# Altium schematic sheet Sheet1.SchDoc(Sheet1)
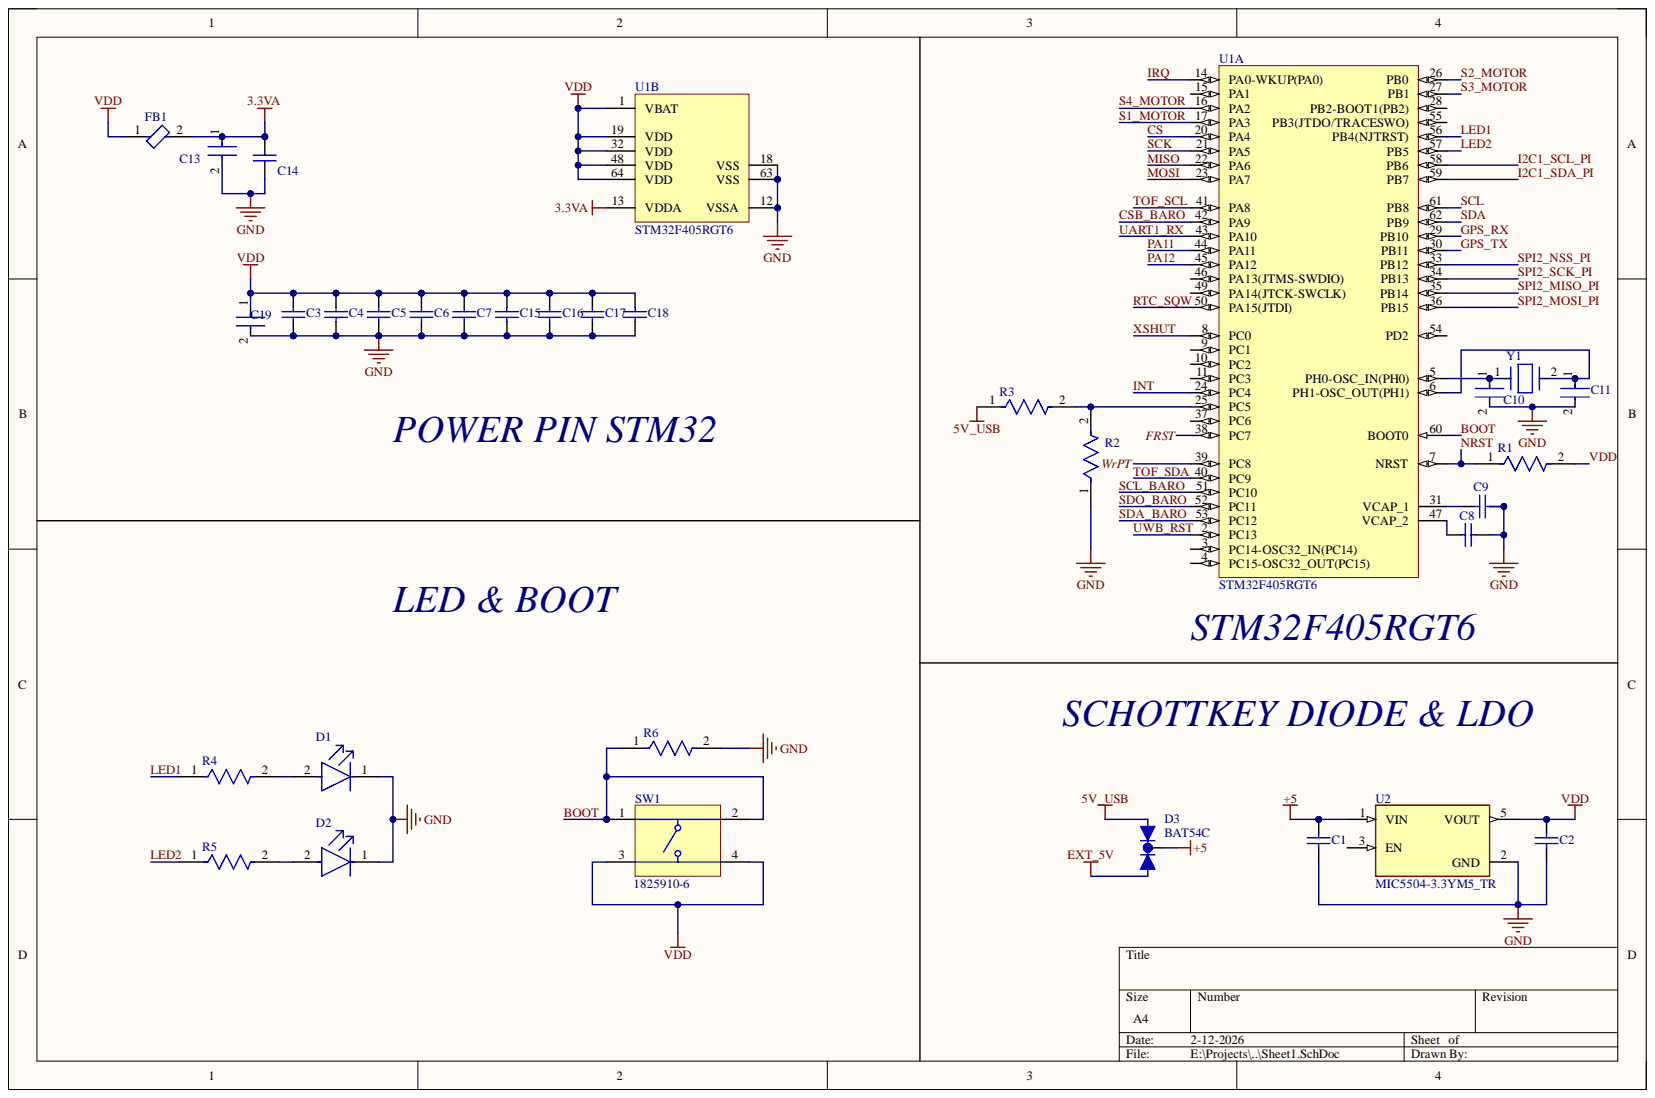
[diagram p0: Sheet1.SchDoc]

## Parts
C1  0603YG105ZAT4A  [CAPC1608X90X35ML15T15]  (value not drawn)
C2  0603YG105ZAT4A  [CAPC1608X90X35ML15T15]  (value not drawn)
C3  VJ0603Y104KXXAP  [CAPC1608X92X38NL15T08]  (value not drawn)
C4  VJ0603Y104KXXAP  [CAPC1608X92X38NL15T08]  (value not drawn)
C5  VJ0603Y104KXXAP  [CAPC1608X92X38NL15T08]  (value not drawn)
C6  VJ0603Y104KXXAP  [CAPC1608X92X38NL15T08]  (value not drawn)
C7  VJ0603Y104KXXAP  [CAPC1608X92X38NL15T08]  (value not drawn)
C8  VJ0603Y104KXXAP  [CAPC1608X92X38NL15T08]  (value not drawn)
C9  VJ0603Y104KXXAP  [CAPC1608X92X38NL15T08]  (value not drawn)
C10  06035A220JAT2A  [FP-0603-L_1_6_0_15-W_0_81-IPC_C]  (value not drawn)
C11  06035A220JAT2A  [FP-0603-L_1_6_0_15-W_0_81-IPC_C]  (value not drawn)
C13  CGA3E2X7R1H104K080AA  [FP-CGA3E-0_3-0_1-IPC_A]  (value not drawn)
C14  GRM188R71H103KA01D  [CAPC1608X90X35ML10T15]  (value not drawn)
C15  VJ0603Y104KXXAP  [CAPC1608X92X38NL15T08]  (value not drawn)
C16  VJ0603Y104KXXAP  [CAPC1608X92X38NL15T08]  (value not drawn)
C17  VJ0603Y104KXXAP  [CAPC1608X92X38NL15T08]  (value not drawn)
C18  VJ0603Y104KXXAP  [CAPC1608X92X38NL15T08]  (value not drawn)
C19  GRM188R60J106ME47D  [FP-GRM188-0_1-e0_2_0_55-IPC_A]  (value not drawn)
D1  LG L29K-G2J1-24-Z  [FP-LG_L29K-MFG]  (value not drawn)
D2  VLMS1300-GS08  [FP-6_541-5092_01-4-MFG]  (value not drawn)
D3  BAT54C  [SOT23-R]
FB1  MPZ2012S101ATD25  [FP-MPZ2012-MFG]  (value not drawn)
R1  AC0603FR-0710KL  [FP-AC0603-MFG]  (value not drawn)
R2  MCT06030C1002FP500  [FP-MCT0603-IPC_C]  (value not drawn)
R3  MCT06030C1002FP500  [FP-MCT0603-IPC_C]  (value not drawn)
R4  AC0603FR-071KL  [FP-AC0603-IPC_B]  (value not drawn)
R5  AC0603FR-071KL  [FP-AC0603-IPC_B]  (value not drawn)
R6  AC0603FR-0710KL  [FP-AC0603-MFG]  (value not drawn)
SW1  1825910-6  [TECO-1825910-6_V]
U1  STM32F405RGT6  [STM-LQFP64_M]  (parts A,B)
U2  MIC5504-3.3YM5_TR  [SOT95P280X145-5N]
Y1  ABM7-8.000MHZ-8-R80-D2Y-T  [FP-ABM7-MFG]  (value not drawn)

## Nets
3.3VA: C13.1 C14.2 FB1.2 U1.13(VDDA)
5V_USB: C1.2 D3.1 D3.3 R3.1 U2.1(VIN)
EXT_5V: D3.2
GND: C1.1 C2.1 C3.1 C4.1 C5.1 C6.1 C7.1 C8.1 C9.1 C10.2 C11.2 C13.2 C14.1 C15.1 C16.1 C17.1 C18.1 C19.2 D1.1 D2.1 R2.1 R6.2 U1.12(VSSA) U1.18(VSS) U1.63(VSS) U2.2(GND)
BOOT: R6.1 SW1.1 SW1.2 U1.60(BOOT0)
CS: U1.20(PA4)
CSB_BARO: U1.42(PA9)
FRST: U1.38(PC7)
GPS_RX: U1.29(PB10)
GPS_TX: U1.30(PB11)
I2C1_SCL_PI: U1.58(PB6)
I2C1_SDA_PI: U1.59(PB7)
INT: U1.24(PC4)
IRQ: U1.14(PA0-WKUP(PA0))
LED1: R4.1 U1.56(PB4(NJTRST))
LED2: R5.1 U1.57(PB5)
MISO: U1.22(PA6)
MOSI: U1.23(PA7)
NRST: R1.1 U1.7(NRST)
PA11: U1.44(PA11)
PA12: U1.45(PA12)
RTC_SQW: U1.50(PA15(JTDI))
S1_MOTOR: U1.17(PA3)
S2_MOTOR: U1.26(PB0)
S3_MOTOR: U1.27(PB1)
S4_MOTOR: U1.16(PA2)
SCK: U1.21(PA5)
SCL: U1.61(PB8)
SCL_BARO: U1.51(PC10)
SDA: U1.62(PB9)
SDA_BARO: U1.53(PC12)
SDO_BARO: U1.52(PC11)
SPI2_MISO_PI: U1.35(PB14)
SPI2_MOSI_PI: U1.36(PB15)
SPI2_NSS_PI: U1.33(PB12)
SPI2_SCK_PI: U1.34(PB13)
TOF_SCL: U1.41(PA8)
TOF_SDA: U1.40(PC9)
UART1_RX: U1.43(PA10)
UWB_RST: U1.2(PC13)
VDD: C2.2 C3.2 C4.2 C5.2 C6.2 C7.2 C15.2 C16.2 C17.2 C18.2 C19.1 FB1.1 R1.2 SW1.3 SW1.4 U1.1(VBAT) U1.19(VDD) U1.32(VDD) U1.48(VDD) U1.64(VDD) U2.5(VOUT)
WrPT: U1.39(PC8)
XSHUT: U1.8(PC0)
NetC8_2: C8.2 U1.47(VCAP_2)
NetC9_2: C9.2 U1.31(VCAP_1)
NetC10_1: C10.1 U1.5(PH0-OSC_IN(PH0)) Y1.1
NetC11_1: C11.1 U1.6(PH1-OSC_OUT(PH1)) Y1.2
NetD1_2: D1.2 R4.2
NetD2_2: D2.2 R5.2
NetR2_2: R2.2 R3.2 U1.25(PC5)

Not connected: U1 3(PC14-OSC32_IN(PC14)) 4(PC15-OSC32_OUT(PC15)) 9(PC1) 10(PC2) 11(PC3) 15(PA1) 28(PB2-BOOT1(PB2)) 37(PC6) 46(PA13(JTMS-SWDIO)) 49(PA14(JTCK-SWCLK)) 54(PD2) 55(PB3(JTDO/TRACESWO)); U2 3(EN)

## Library data of the parts
C1 C2 = CMP-2006-04543-1: Manufacturer: AVX Interconnect / Elco; Component Kind: Standard; Library Name: Altium Content Vault; Part Number: 0603YG105ZAT4A
C3-C7 C15-C18 = CMP-2006-01166-1: Manufacturer: Vitramon; Component Kind: Standard; Library Name: Altium Content Vault; Part Number: VJ0603Y104KXXAP
C8 C9 = CMP-2006-01166-1: 100nf; Manufacturer: Vitramon; Component Kind: Standard; Library Name: Altium Content Vault; Part Number: VJ0603Y104KXXAP
C10 C11 = CMP-2006-04207-3: General Purpose Ceramic Capacitor, 0603, 22pF, 5%, NP0, 30ppm/°C, 50V; Dielectric: C0G; Insulation Resistance: 100GR; Capacitance: 22pF; Case Code (Imperial): 0603; Case Code (Metric): 1608; Case/Package: 0603; Component Kind: Standard; Contact Plating: Tin; Depth: 0.81mm; Dielectric Material: Ceramic; Height: 0.63mm; Lead Free: Lead Free; Length: 1.6mm; Library Name: Altium Content Vault; Max Operating Temperature: 125°C; Min Operating Temperature: -55°C; Mount: Surface Mount; Number of Pins: 2; Package Quantity: 1; Packaging: Tape and Reel; Radiation Hardening: No; REACH SVHC: No SVHC; RoHS Compliant: Yes; Temperature Coefficient: 30ppm/°C; Termination: SMD/SMT; Thickness: 0.035inch; Tolerance: 5%; Voltage: 50V; Voltage Rating: 50V; Voltage Rating (DC): 50V; Width: 0.81mm
C13 = CMP-2000-07546-2: Cap Ceramic 100nF 50V X7R 10% Pad SMD 0603 +125°C Automotive T/R; Capacitance: 100nF; Case Code (Imperial): 0603; Case Code (Metric): 1608; Case/Package: 0603; Component Kind: Standard; Composition: Ceramic; Depth: 0.8mm; Dielectric: X7R; Height: 0.8mm; Lead Free: Lead Free; Length: 1.6mm; Library Name: Altium Content Vault; Max Operating Temperature: 125°C; Min Operating Temperature: -55°C; Mount: Surface Mount; Package Quantity: 1; Packaging: Tape and Reel; Radiation Hardening: No; Ratings: AEC-Q200; REACH SVHC: No SVHC; Termination: SMD/SMT; Thickness: 0.035inch; Tolerance: 10%; Voltage: 50V; Voltage Rating: 50V; Voltage Rating (DC): 50V; Weight: 7.1E-05oz; Width: 0.8mm
C14 = CMP-2100-03642-1: Chip Capacitor, 10 nF, +/- 10%, 50 V, -55 to 125 degC, 0603 (1608 Metric), RoHS, Tape and Reel; Manufacturer: Murata; Capacitance: 10nF; Dielectric: X7R; Dielectric Material: Ceramic; Insulation Resistance: 10GR; Voltage: 50V; Case Code (Imperial): 0603; Case Code (Metric): 1608; Case/Package: 0603; Component Kind: Standard; Datasheet URL: http://search.murata.co.jp/Ceramy/image/img/A01X/G101/ENG/GRM188R71H103KA01-01.pdf; Datasheet Version: 42401.0; Depth: 0.8mm; Height: 0.8mm; Lead Free: LeadFree; Length: 1.6mm; Library Name: Altium Content Vault; Manufacturer URL: http://www.murata.com/; Material: Ceramic; Max Operating Temperature: 125degC; Min Operating Temperature: -55degC; Mount: SurfaceMount; Number of Pins: 2; Package Description: 2-Pin Surface Mount Device, Body 1.6 x 0.8 mm; Package Quantity: 1; Package Reference: 0603; Packaging: TapeandReel; REACH SVHC: Unknown; RoHSCompliant: True; Termination: SMD/SMT; Thickness: 0.035inch; Tolerance: 10%; Voltage Rating: 50V; Voltage Rating (DC): 50V; Width: 0.031inch
C19 = CMP-2006-02792-2: Chip Multilayer Ceramic Capacitors for General Purpose, 0603, 10uF, X5R, 15%, 20%, 6.3V; Capacitance: 10uF; Case Code (Imperial): 0603; Case Code (Metric): 1608; Case/Package: 0603; Component Kind: Standard; Depth: 0.8mm; Dielectric: X5R; Height: 0.8mm; Lead Free: Lead Free; Length: 1.6mm; Library Name: Altium Content Vault; Material: Ceramic; Max Operating Temperature: 85°C; Min Operating Temperature: -55°C; Mount: Surface Mount; Package Quantity: 4000; Packaging: Tape and Reel; Radiation Hardening: No; REACH SVHC: No SVHC; Termination: SMD/SMT; Thickness: 0.035inch; Tolerance: 20%; Voltage: 6.3V; Voltage Rating: 6.3V; Voltage Rating (DC): 6.3V; Width: 0.031inch
D1 = CMP-62016-000024-1: LED GREEN DIFFUSED 0603 SMD; Dominant Wavelength: 570nm; Forward Current: 20mA; Forward Voltage: 2.2V; Lens Color: White; Lens Size: 0.8mm; Lens Style: Flat; Luminous Flux: 0.012lm; Luminous Intensity: 5.6mcd; Number of Elements: 1; Reverse Voltage: 12V; Test Current: 2mA; Viewing Angle: 160°; Case Code (Imperial): 0603; Case Code (Metric): 1608; Case/Package: 0603; Color: Green; Component Kind: Standard; Depth: 0.8mm; Height: 0.65mm; Illumination Color: Green; Length: 1.3mm; Lens Transparency: Diffused; Library Name: Altium Content Vault; Max Operating Temperature: 100°C; Min Operating Temperature: -40°C; Mount: Surface Mount; Number of LEDs: 1; Number of Pins: 2; Package Quantity: 5000; Packaging: Tape and Reel; Radiation Hardening: No; Width: 0.8mm
D2 = CMP-02520-000012-1: LED RED 0603 SMD; Manufacturer: Vishay; Forward Current: 20mA; Forward Voltage: 2V; Led Orientation: Top View; Luminous Intensity: 54mcd; Peak Emmision Wavelength: 639nm; Power Dissipation: 75mW; Reverse Voltage: 5V; Viewing Angle: 130°; Wavelength: 631nm; Ambient Temperature Range High: +85°C; Ambient Temperature Range Low: -35°C; Automotive: No; Category: Diode; Colour: Red; Component Kind: Standard; ComponentLink2Description: Datasheet; ComponentLink2URL: http://www.vishay.com/docs/82437/vlmo1300.pdf; Device Class L1: Optoelectronics; Device Class L2: LEDs; Device Class L3: unset; Digikey Description: LED RED 0603 SMD; Height: 1mm; Lead Free: Yes; Library Name: Altium Content Vault; Manufacturer Part Number: VLMS1300-GS08; Mouser Description: Standard LEDs - SMD Super Red Clear Non-Diff; Octopart: https://octopart.com/vlms1300-gs08-vishay-21709201; Package: 6.541-5092.01-4; RoHS: TRUE; Temperature: -35°C to +85°C; Temperature Range High: +85°C; Temperature Range Low: -35°C
D3 = BAT54C: DIODE, SCHOTTKY, DUAL; Diode Type:Schottky; Voltage, Vrrm:30V; Current, If AV:300mA; Current, Ifsm:1A; Voltage, Vf Max:0.4V; Max Junction Temperature, Tj:150Â°C; Termination Type:SMD; Case Style:SOT-23; No. of Pins:3; Current, If ;RoHS Compliant: Yes; MF: ON Semiconductor; Check_prices: https://www.snapeda.com/parts/BAT54C/Onsemi/view-part/?ref=eda; Component Kind: Standard; Library Name: BAT54C.SchLib; MP: BAT54C; Package: SOT-23-3 ON Semiconductor; SnapEDA_Link: https://www.snapeda.com/parts/BAT54C/Onsemi/view-part/?ref=snap
FB1 = CMP-08257-000007-1: FERRITE BEAD 100 OHM 0805 1LN; DC Resistance (DCR): 20mR; Impedance: 100R; Max Current Rating: 4A; Number of Lines: 1; Test Frequency: 100MHz; Tolerance: 25%; Case Code (Imperial): 0805; Case Code (Metric): 2012; Case/Package: 0805; Component Kind: Standard; Core Material: Ferrite; Depth: 1.25mm; Height: 0.85mm; Lead Free: Lead Free; Length: 0.079inch; Library Name: Altium Content Vault; Max DC Current: 4A; Max Operating Temperature: 125°C; Min Operating Temperature: -55°C; Mount: Surface Mount; Package Quantity: 4000; Packaging: Tape and Reel; Ratings: AEC-Q200; Termination: SMD/SMT; Weight: 0.000194oz; Width: 1.25mm
R1 R6 = CMP-2000-04818-3: RES 10KΩ 1.00% 0.1W 0603; Max Power Dissipation: 100mW; Resistance: 10kR; Temperature Coefficient: 100ppm/°C; Tolerance: 1%; Voltage Rating: 50V; Case Code (Imperial): 0603; Case Code (Metric): 1608; Case/Package: 0603; Component Kind: Standard; Construction: Rectangular; Depth: 0.8mm; Features: Moisture Resistant; Height: 0.45mm; Lead Free: Lead Free; Length: 1.6mm; Library Name: Altium Content Vault; Max Operating Temperature: 155°C; Military Standard: Not; Min Operating Temperature: -55°C; Mount: Surface Mount; Number of Terminations: 2; Package Quantity: 1; Packaging: Tape and Reel; Power Rating: 100mW; Ratings: AEC-Q200; Resistor Type: Thick Film; RoHS Compliant: Yes; Series: AC; Size Code: 0603; Termination: SMD/SMT; Width: 0.8mm
R2 R3 = CMP-02407-000418-1: RES SMD 100 OHM 1% 1/8W 0603; Max Power Dissipation: 125mW; Power Rating: 100mW; Resistance: 10kR; Temperature Coefficient: 50ppm/°C; Voltage Rating: 75V; Case Code (Imperial): 0603; Case Code (Metric): 1608; Case/Package: 0603; Component Kind: Standard; Composition: Thin Film; Contact Plating: Tin; Depth: 0.85mm; Height: 0.022inch; Lead Free: Lead Free; Length: 1.55mm; Library Name: Altium Content Vault; Max Operating Temperature: 125°C; Military Standard: Not; Min Operating Temperature: -55°C; Mount: Surface Mount; Number of Terminations: 2; Package Quantity: 1; Packaging: Tape and Reel; Radiation Hardening: No; Tolerance: 1%; Voltage Rating (DC): 75V; Width: 0.8mm
R4 R5 = CMP-2000-04828-3: RES 1kΩ 1.00% 0.1W 0603; Max Power Dissipation: 100mW; Resistance: 1kR; Temperature Coefficient: 100ppm/°C; Tolerance: 1%; Voltage Rating: 50V; Case Code (Imperial): 0603; Case Code (Metric): 1608; Case/Package: 0603; Component Kind: Standard; Construction: Rectangular; Depth: 0.8mm; Features: Moisture Resistant; Height: 0.022inch; Lead Free: Lead Free; Length: 0.063inch; Library Name: Altium Content Vault; Max Operating Temperature: 155°C; Military Standard: Not; Min Operating Temperature: -55°C; Number of Terminations: 2; Package Quantity: 1; Packaging: Tape and Reel; Power Rating: 100mW; Ratings: AEC-Q200; Resistor Type: Thick Film; RoHS Compliant: Yes; Series: AC; Size Code: 0603; Termination: SMD/SMT; Width: 0.031inch
SW1 = CMP-1684-00021-1: Tact Switch, SPST-NO, 0.05 A, -35 to 85 degC, 4-Pin THD, RoHS, Bulk; Manufacturer: TE Connectivity; Actuator Color: Black; Actuator Length: 1.4mm; Actuator Material: PolybutyleneTerephthalate; Actuator Style: RoundButton; Body Orientation: Straight; Bushing Type: Unthreaded; Contact Rating @ Voltage: 0.05A@24VDC; Dielectric Strength: 500VAC; Electrical Life: 100000Cycles; Function: MomentaryContact; Illumination: N/R; Maximum Contact Resistance: 100mOhm; Maximum DC Voltage Rating: 24V; Pole Throw Configuration: SPST; Switch Configuration: OFF(ON); Base Material: N/A; Component Kind: Standard; Contact Material: CopperAlloy; Contact Plating: Silver; Datasheet URL: http://www.te.com/commerce/DocumentDelivery/DDEController?Action=showdoc&DocId=Customer+Drawing%7F1825910%7FC9%7Fpdf%7FEnglish%7FENG_CD_1825910_C9.pdf%7F1825910-6; Datasheet Version: Rev. C9; Dimension: 5.99x5mm; Family: 1825910; Housing Material: N/A; Library Name: Altium Content Vault; Manufacturer URL: http://www.te.com; Maximum AC Voltage Rating: N/A; Mechanical Life: 100000Cycles; Minimum Insulation Resistance: 100MOhm; Mounting: ThroughHole; Operating Temperature: -35to85C; Package Description: 4-Pin Through Hole Device, Body 5.99 x 5.99 mm, Pitch 6.5 mm; Package Reference: THD; Packaging: N/A; Part No: 1825910-6; Product Weight: N/A; RoHS: Yes; Seal Description: N/A; Seal Location: N/A; Terminal Type: PCPins; Thread Size: N/R
U1 = CMP-0243-00019-3: ARM Cortex-M4 32-bit MCU+FPU, 210 DMIPS, 1024 kB Flash, 192 kB Internal RAM, 51 I/Os, 64-pin LQFP, -40 to 85 degC, Tray; Manufacturer: STMicroelectronics; D/A Converter: 2x12-bit; FLASH Size (Prog) (kB): 1024; I/Os (High Current): 51; Internal RAM Size (kB): 192; Operating Frequency(F) (Processor speed) (MHz): 168; Supply Voltage(Vcc) max (V): 3.6; Supply Voltage(Vcc) min (V): 1.8; Component Kind: Standard; ComponentLink1Description: Manufacturer URL; ComponentLink1URL: http://www.st.com/web/en/home.html; ComponentLink2Description: Datasheet; ComponentLink2URL: http://www.st.com/st-web-ui/static/active/en/resource/technical/document/datasheet/DM00037051.pdf; ComponentLink3Description: Package Specification; ComponentLink3URL: http://www.st.com/st-web-ui/static/active/en/resource/technical/document/datasheet/DM00037051.pdf; Core: ARM Cortex-M4; DatasheetVersion: Rev. 4; Library Name: Altium Content Vault; Mounting Technology: Surface Mount; PackageDescription: 64-Pin Low Profile Quad Flat Package, 10 x 10 mm Body, 0.5 mm Pitch; PackageReference: LQFP64; PackageVersion: Rev. 4, 06/2013; Packing: Tray; PartNumber: STM32F405RGT6
U2 = MIC5504-3.3YM5_TR: Linear Voltage Regulator IC Positive Fixed 1 Output 300mA SOT-23-5; MF: Microchip; MP: MIC5504-3.3YM5 TR; Check_prices: https://www.snapeda.com/parts/MIC5504-3.3YM5%20TR/Microchip/view-part/?ref=eda; Component Kind: Standard; Library Name: MIC5504-3.3YM5_TR.SchLib; Package: SOT-23 Microchip; SnapEDA_Link: https://www.snapeda.com/parts/MIC5504-3.3YM5%20TR/Microchip/view-part/?ref=snap
Y1 = CMP-27762-013691-1: Crystal 8MHz ±20ppm 8pF SMD-2 6mm x 3.5mm; ESR (Equivalent Series Resistance): 80R; Frequency Stability: 30ppm; Case/Package: SMD/SMT; Component Kind: Standard; Frequency: 8MHz; Frequency Tolerance: 20ppm; Library Name: Altium Content Vault; Load Capacitance: 8pF; Max Operating Temperature: 85°C; Min Operating Temperature: -40°C; Mount: Surface Mount; Packaging: Tape and Reel
